# Altium schematic sheet Main.SchDoc
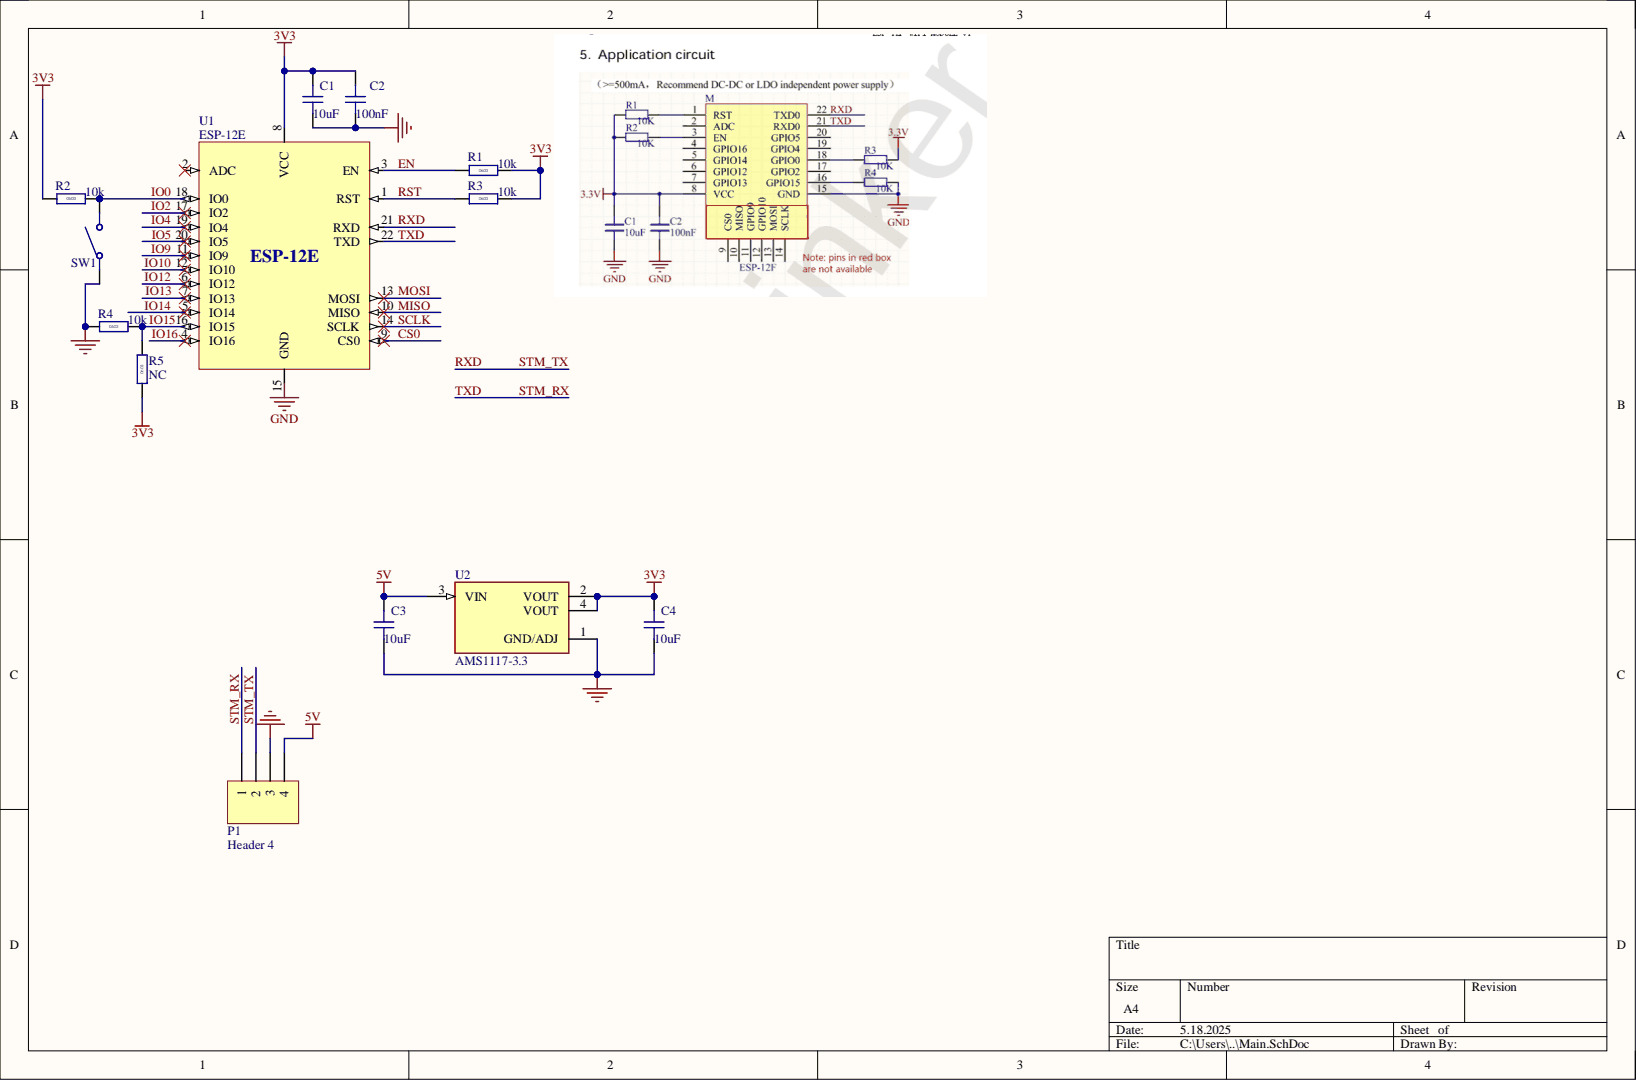
[diagram p0: Main.SchDoc]

## Parts
C1  10uF  [CAP_0603]
C2  100nF  [CAP_0603]
C3  10uF  [CAP_0603]
C4  10uF  [CAP_0603]
P1  Header 4  [HDR1X4]
R1  10k  [RES_0603]
R2  10k  [RES_0603]
R3  10k  [RES_0603]
R4  10k  [RES_0603]
R5  NC  [RES_0603]
SW1  BOOT  [TS-1088-AR02016-Footprint-1]  (value not drawn)
U1  ESP-12E  [ESP-12E]
U2  AMS1117-3.3  [SOT229P700X180-4N]

## Nets
3V3: C1.2 C2.2 C4.2 R1.2 R2.1 R3.2 R5.1 U1.8(VCC) U2.2(VOUT) U2.4(VOUT)
5V: C3.2 P1.4 U2.3(VIN)
GND: C1.1 C2.1 C3.1 C4.1 P1.3 R4.1 SW1.1 U1.15(GND) U2.1(GND/ADJ)
CS0: U1.9(CS0)
EN: R1.1 U1.3(EN)
IO0: R2.2 SW1.2 U1.18(IO0)
IO2: U1.17(IO2)
IO4: U1.19(IO4)
IO5: U1.20(IO5)
IO9: U1.11(IO9)
IO10: U1.12(IO10)
IO12: U1.6(IO12)
IO13: U1.7(IO13)
IO14: U1.5(IO14)
IO15: R4.2 R5.2 U1.16(IO15)
IO16: U1.4(IO16)
MISO: U1.10(MISO)
MOSI: U1.13(MOSI)
RST: R3.1 U1.1(RST)
RXD: P1.2 U1.21(RXD)
SCLK: U1.14(SCLK)
STM_RX: P1.1 U1.22(TXD)

Not connected: U1 2(ADC)

## Library data of the parts
C1-C4 = CMP-001-00086-1: Capacitor SMD 0603; Component Kind: Standard; Design Item ID: CAP_0603; Library Name: Simon Mayr; Source: Schematic-Lib-PhilsLab.SchLib
P1 = CMP-002-00209-1: Header, 4-Pin; Component Kind: Standard; Design Item ID: Header 4; LatestRevisionDate: 17-Jul-2002; LatestRevisionNote: Re-released for DXP Platform.; Source: Miscellaneous Connectors.SchLib; Type: Connector; Library Name: Simon Mayr; Publisher: Altium Limited
R1-R5 = CMP-009-00189-1: Resistor SMD 0603; Component Kind: Standard; Design Item ID: RES_0603; Library Name: Simon Mayr; Source: Schematic-Lib-PhilsLab.SchLib
SW1 = CMP-011-00014-2: Without 50mA 4mm 100MΩ 100000 Times 12V 160gf 3mm 2mm Round Button Standing paste SPST SMD Tactile Switches ROHS; Component Kind: Standard; Pins: 2; Library Name: Simon Mayr
U1 = CMP-074-000010-1: ESP8266 ESP-12E Module (ESP8266, Castellated Holes); Manufacturer: AI-THINKER; Component Kind: Standard; Design Item ID: ESP-12E; Manufacturer Part Number: ESP-12E; Library Name: Simon Mayr; Source: Schematic-Lib-PhilsLab.SchLib
U2 = CMP-014-00002-2: Sot223/Pkg 1-Amp 3.3-Volt Low Drop Out Voltage Regulatator; MF: Advanced Monolithic Systems; Availability: Not in stock; Case/Package: SOT-223 Seeed Technology; Check_prices: https://www.snapeda.com/parts/AMS1117-3.3/Advanced+Monolithic+Systems/view-part/?ref=eda; Component Kind: Standard; Component Type: Standard; Design Item ID: AMS1117-3.3; MP: AMS1117-3.3; Pins: 3; Price: None; SnapEDA_Link: https://www.snapeda.com/parts/AMS1117-3.3/Advanced+Monolithic+Systems/view-part/?ref=snap; Source: AMS1117-3.3.SchLib; Library Name: Simon Mayr
